# Altium schematic sheet H bridge.SchDoc
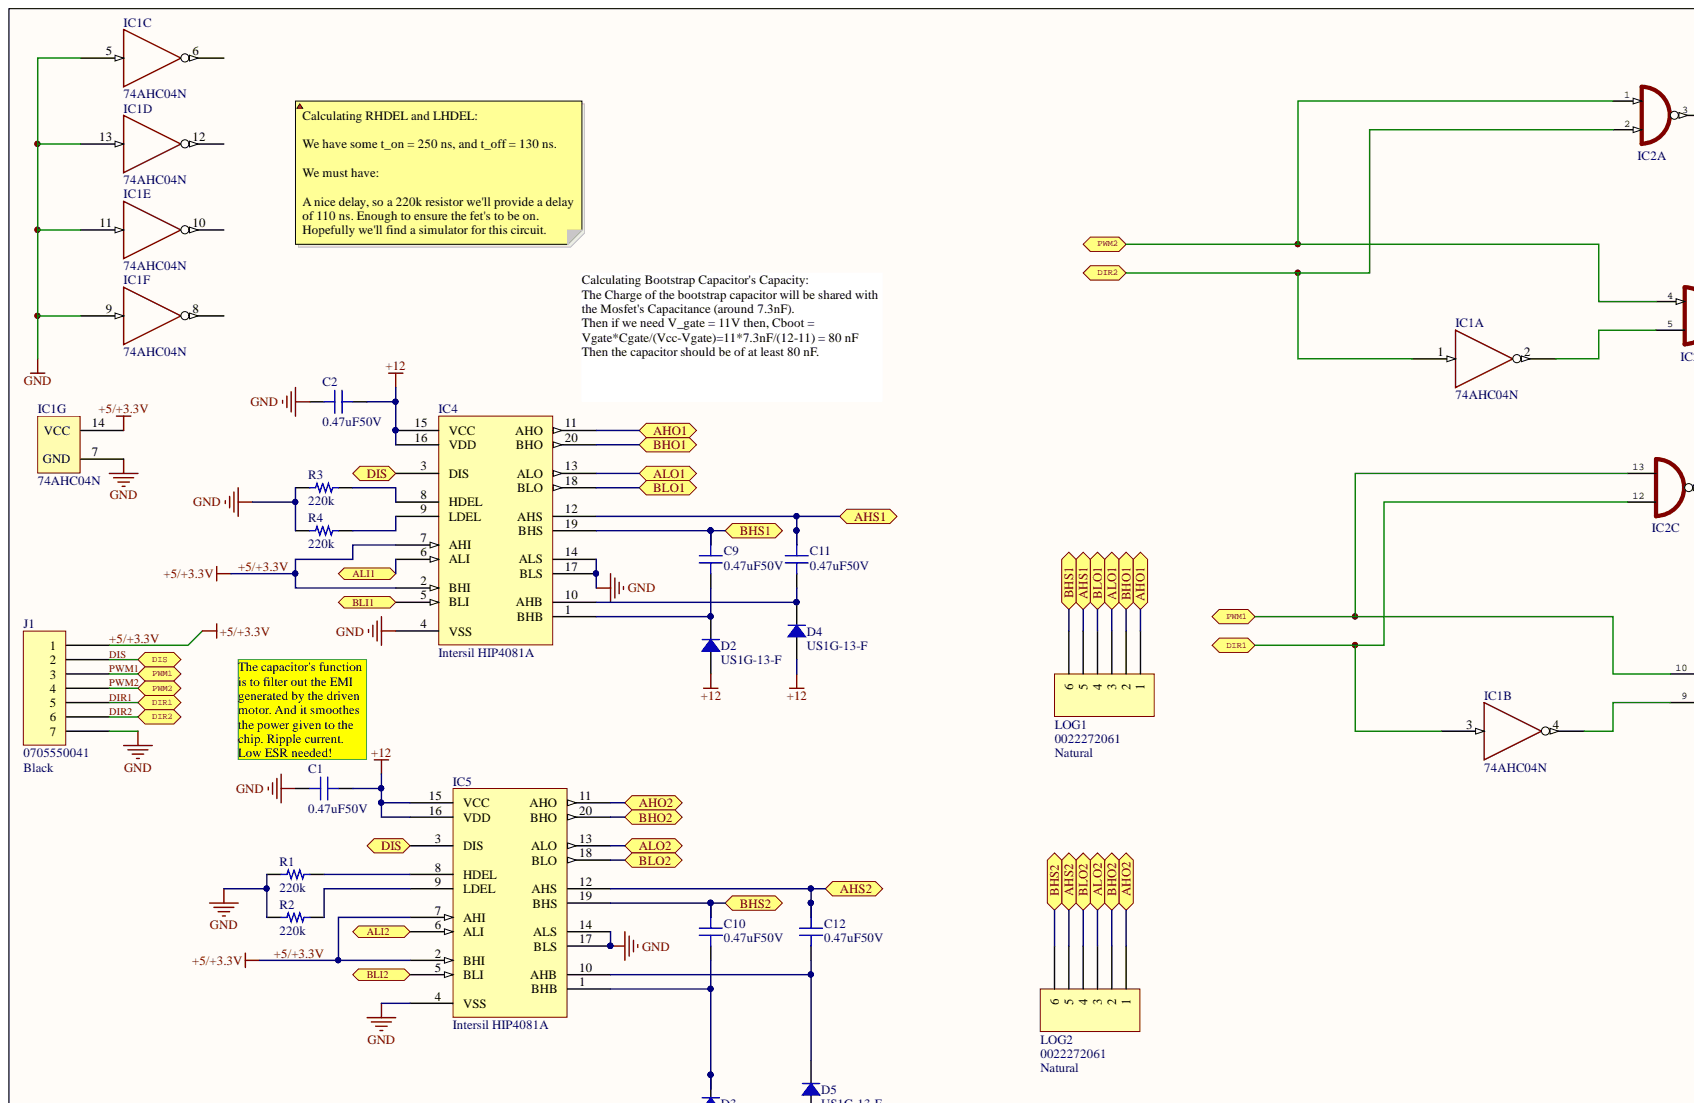
[diagram p0: H bridge.SchDoc, top left]
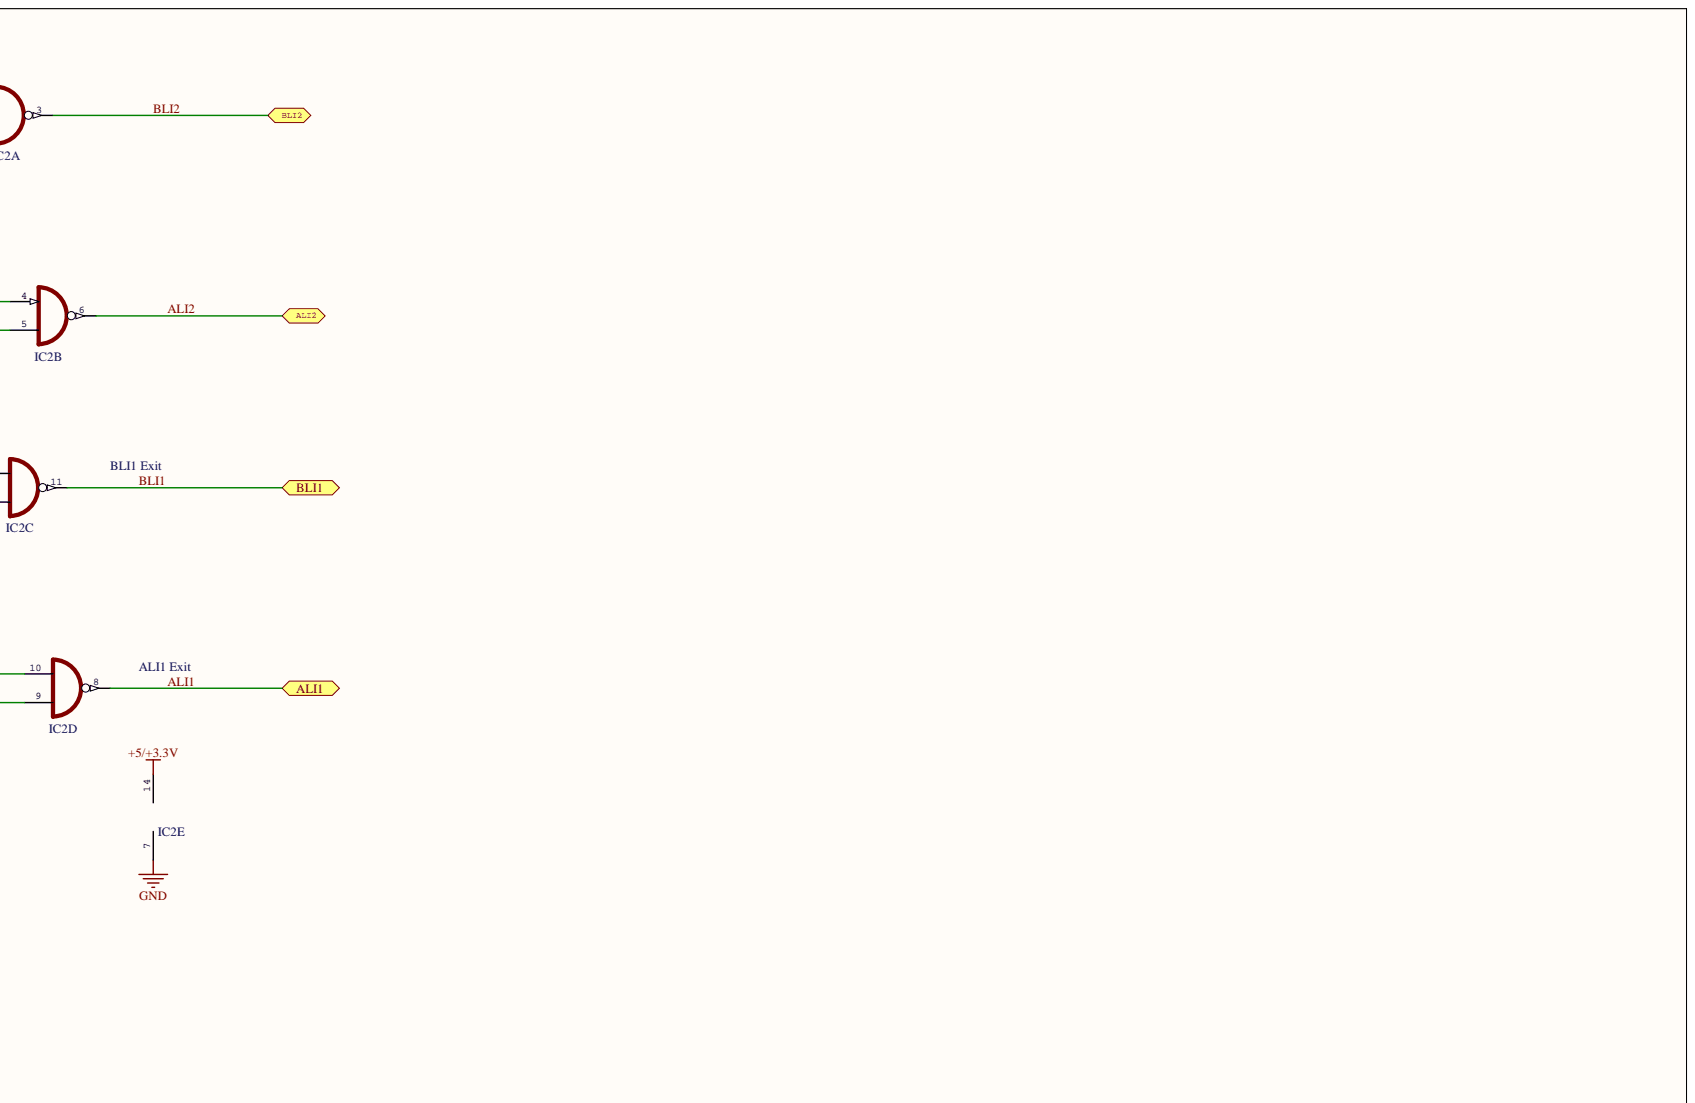
[diagram p1: H bridge.SchDoc, top right]
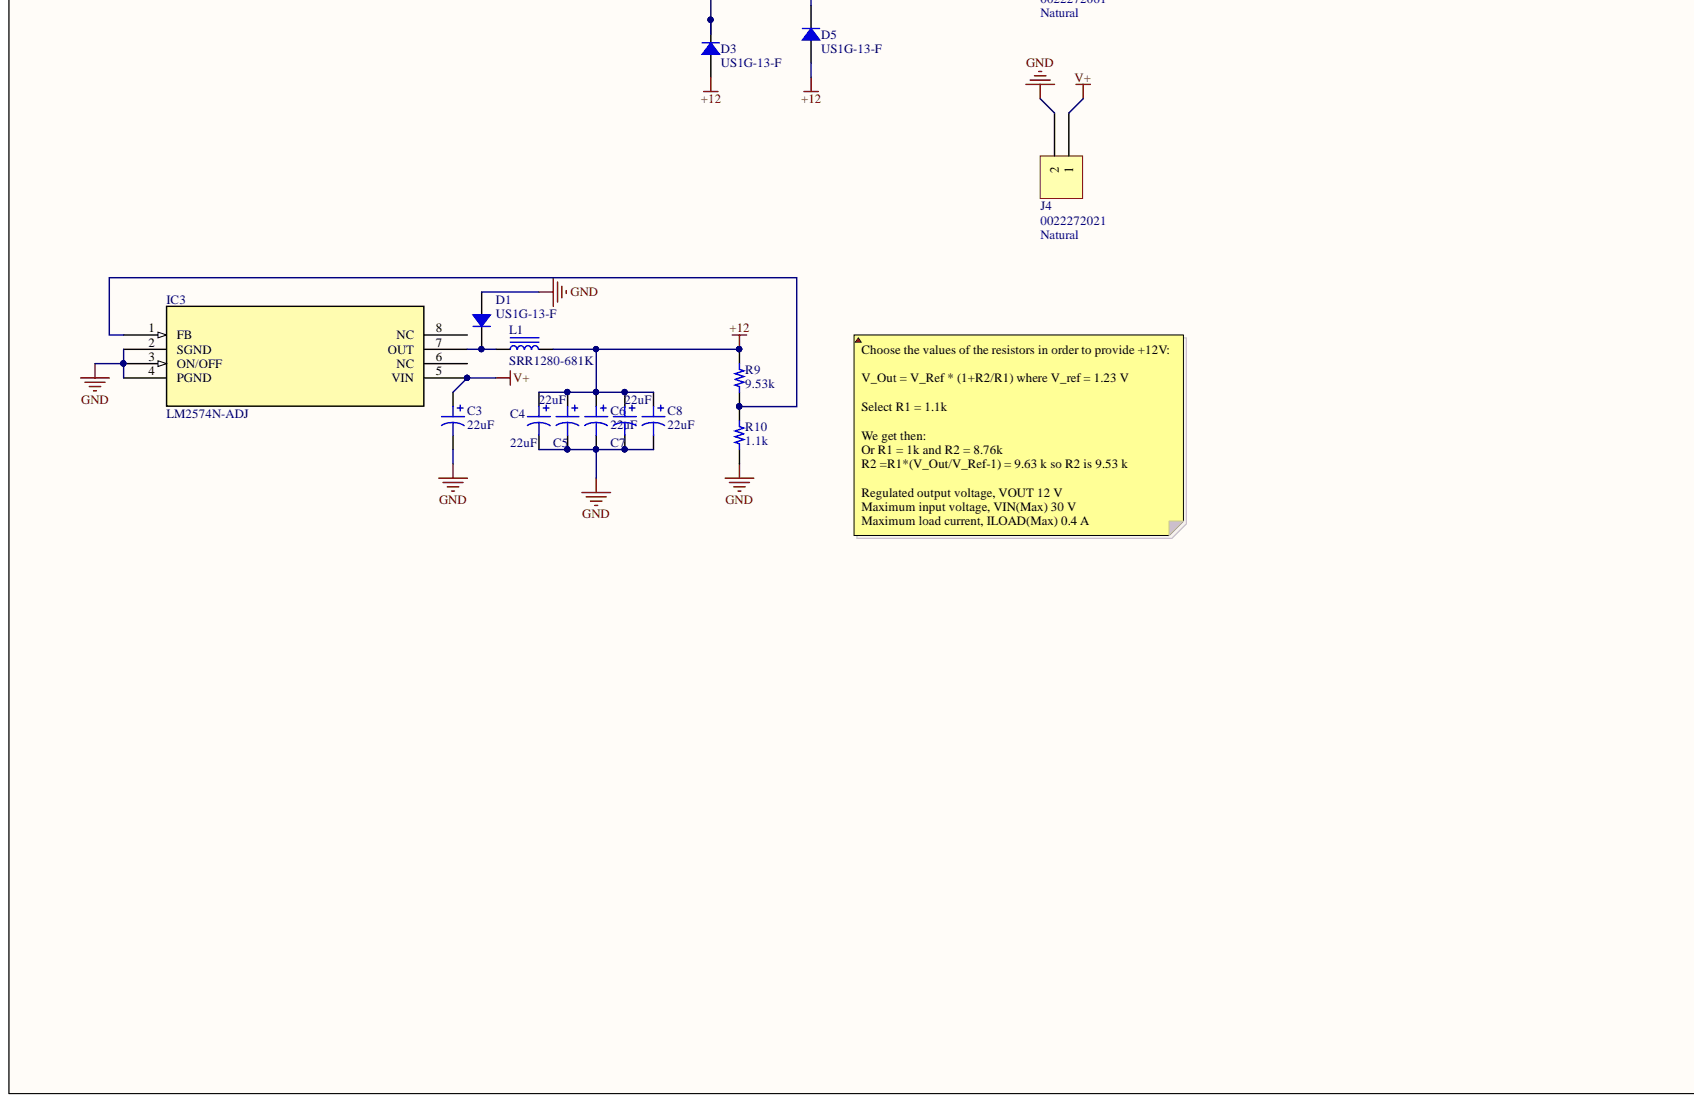
[diagram p2: H bridge.SchDoc, bottom left]

## Parts
C1  0.47uF50V  [CAP 1812/4532 1.1MM]
C2  0.47uF50V  [CAP 1812/4532 1.1MM]
C3  22uF  [CAP ALUM 8.3MM]
C4  22uF  [CAP ALUM 8.3MM]
C5  22uF  [CAP ALUM 8.3MM]
C6  22uF  [CAP ALUM 8.3MM]
C7  22uF  [CAP ALUM 8.3MM]
C8  22uF  [CAP ALUM 8.3MM]
C9  0.47uF50V  [CAP 1812/4532 1.1MM]
C10  0.47uF50V  [CAP 1812/4532 1.1MM]
C11  0.47uF50V  [CAP 1812/4532 1.1MM]
C12  0.47uF50V  [CAP 1812/4532 1.1MM]
D1  US1G-13-F  [DO214AC SMA]
D2  US1G-13-F  [DO214AC SMA]
D3  US1G-13-F  [DO214AC SMA]
D4  US1G-13-F  [DO214AC SMA]
D5  US1G-13-F  [DO214AC SMA]
IC1  74AHC04N  [DIL14]  (parts A,B,C,D,E,F,G)
IC2  PartNumber  [DIL14]  (value not drawn)  (parts A,B,C,D,E)
IC3  LM2574N-ADJ  [DIP8]
IC4  Intersil HIP4081A  [DIP20]
IC5  Intersil HIP4081A  [DIP20]
J1  0705550041  Colour: Black  [MOLEX SDA-70555-7PIN]
J4  0022272021  Colour: Natural  [MOLEX KK 0022272021]
L1  SRR1280-681K  [BOURNS SRR1280]
LOG1  0022272061  Colour: Natural  [MOLEX KK 0022272061]
LOG2  0022272061  Colour: Natural  [MOLEX KK 0022272061]
R1  220k  [RES 0603/1608]
R2  220k  [RES 0603/1608]
R3  220k  [RES 0603/1608]
R4  220k  [RES 0603/1608]
R9  9.53k  [RES 1206/3216]
R10  1.1k  [RES 1206/3216]

## Ports
AHO1, AHO2, AHS1, AHS2, ALI1, ALI2, ALO1, ALO2, BHO1, BHO2, BHS1, BHS2, BLI1, BLI2, BLO1, BLO2, DIR1, DIR2, DIS, PWM1, PWM2

## Nets
+12: C1.2 C2.2 C4.1 C5.1 C6.1 C7.1 C8.1 D2.1 D3.1 D4.1 D5.1 IC4.15(VCC) IC4.16(VDD) IC5.15(VCC) IC5.16(VDD) L1.2 R9.2
GND: C1.1 C2.1 C3.2 C4.2 C5.2 C6.2 C7.2 C8.2 D1.1 IC1.5 IC1.7(GND) IC1.9 IC1.11 IC1.13 IC2.7 IC3.2(SGND) IC3.3(ON/OFF) IC3.4(PGND) IC4.4(VSS) IC4.14(ALS) IC4.17(BLS) IC5.4(VSS) IC5.14(ALS) IC5.17(BLS) J1.7 J4.2 R1.1 R2.1 R3.1 R4.1 R10.2
V+: C3.1 IC3.5(VIN) J4.1
+5/+3.3V: IC1.14(VCC) IC2.14 IC4.2(BHI) IC4.7(AHI) IC5.2(BHI) IC5.7(AHI) J1.1
AHO1: IC4.11(AHO) LOG1.1 ; port AHO1
AHO2: IC5.11(AHO) LOG2.1 ; port AHO2
AHS1: C11.2 IC4.12(AHS) LOG1.5 ; port AHS1
AHS2: C12.2 IC5.12(AHS) LOG2.5 ; port AHS2
ALI1: IC2.8 IC4.6(ALI) ; port ALI1
ALI2: IC2.6 IC5.6(ALI) ; port ALI2
ALO1: IC4.13(ALO) LOG1.3 ; port ALO1
ALO2: IC5.13(ALO) LOG2.3 ; port ALO2
BHO1: IC4.20(BHO) LOG1.2 ; port BHO1
BHO2: IC5.20(BHO) LOG2.2 ; port BHO2
BHS1: C9.2 IC4.19(BHS) LOG1.6 ; port BHS1
BHS2: C10.2 IC5.19(BHS) LOG2.6 ; port BHS2
BLI1: IC2.11 IC4.5(BLI) ; port BLI1
BLI2: IC2.3 IC5.5(BLI) ; port BLI2
BLO1: IC4.18(BLO) LOG1.4 ; port BLO1
BLO2: IC5.18(BLO) LOG2.4 ; port BLO2
DIR1: IC1.3 IC2.12 J1.5 ; port DIR1
DIR2: IC1.1 IC2.2 J1.6 ; port DIR2
DIS: IC4.3(DIS) IC5.3(DIS) J1.2 ; port DIS
PWM1: IC2.10 IC2.13 J1.3 ; port PWM1
PWM2: IC2.1 IC2.4 J1.4 ; port PWM2
NetC9_1: C9.1 D2.2 IC4.1(BHB)
NetC10_1: C10.1 D3.2 IC5.1(BHB)
NetC11_1: C11.1 D4.2 IC4.10(AHB)
NetC12_1: C12.1 D5.2 IC5.10(AHB)
NetD1_2: D1.2 IC3.7(OUT) L1.1
NetIC1_2: IC1.2 IC2.5
NetIC1_4: IC1.4 IC2.9
NetIC3_1: IC3.1(FB) R9.1 R10.1
NetIC4_8: IC4.8(HDEL) R3.2
NetIC4_9: IC4.9(LDEL) R4.2
NetIC5_8: IC5.8(HDEL) R1.2
NetIC5_9: IC5.9(LDEL) R2.2

Not connected: IC1 6 8 10 12; IC3 6(NC) 8(NC)

## Library data of the parts
C1 C2 C9-C12 = C1812C474K5RACTU: Ceramic, Commercial-(CxxxxC), 0.47 uF, 10%, 50 V,; Manufacturer: Kemet; Database Table Name: Capacitor - Ceramic; TemperatureCoefficient: X7R; TemperatureRange: -55°C ~ 125°C; Value: 0.47uF; Voltage: 50V; Component Kind: Standard; ComponentLink1Description: Datasheet; ComponentLink1URL: http://www.kemet.com/docfinder?Partnumber=C1812C474K5RACTU; ComponentLink2Description: Digi-Key Listing; ComponentLink2URL: https://www.digikey.com/product-detail/en/kemet/C1812C474K5RACTU/399-3454-1-ND/721325; Device Package: 1812 (4532 Metric); Library Name: Celestial Library Database.DbLib; PartId: 21099; Part Number: C1812C474K5RACTU; Price: 0.97; Supplier 1: Digi-Key; Supplier Part Number 1: 399-3454-1-ND; Tolerance: 10%
C3-C8 = UWX1H220MCL1GB: CAP ALUM 22UF 20% 50V SMD; Manufacturer: Nichicon; Database Table Name: Capacitor - Aluminium; Lifetime @ Temp: 2000 Hrs @ 85C; Ripple Current: 52mA; TemperatureRange: -40C ~ 85C; Type: General Purpose; Component Kind: Standard; ComponentLink1Description: Datasheet; ComponentLink1URL: http://nichicon-us.com/english/products/pdfs/e-wx.pdf; ComponentLink2Description: Digi-Key listing; ComponentLink2URL: http://digikey.com/product-detail/en/UWX1H220MCL1GB/493-2136-2-ND/589877; Device Package: Radial, Can - SMD; Impedance: -; Library Name: Celestial Library Database.DbLib; PartId: 7298; Part Number: UWX1H220MCL1GB; Price: 0.1154; Supplier 1: Digi-Key; Supplier Part Number 1: 493-2136-2-ND; Tolerance: 20%; Value: 22uF; Voltage: 50V
D1-D5 = US1G-13-F: DIODE GEN PURP 400V 1A SMA; Manufacturer: Diodes Incorporated; Current Reverse Leakage @ Vr: 5uA @ 400V; Database Table Name: Diode - Rectifier; Reverse Recovery Time (trr): 50ns; Type: Standard; Voltage Forward (Vf): 1.3V @ 1A; Component Kind: Standard; ComponentLink1Description: Datasheet; ComponentLink1URL: http://www.diodes.com/_files/datasheets/ds16008.pdf; ComponentLink2Description: Digi-Key Listing; ComponentLink2URL: https://www.digikey.com/product-detail/en/diodes-incorporated/US1G-13-F/US1G-FDITR-ND/814656; Current Rectified: 1A; Device Package: DO-214AC, SMA; Junction Operating Temperature: -65C ~ 150C; Library Name: Celestial Library Database.DbLib; PartId: 16329; Part Number: US1G-13-F; Price: 0.0935; Supplier 1: Digi-Key; Supplier Part Number 1: US1G-FDITR-ND; Voltage DC Reverse (Vr): 400V
IC2 = SN74AC08N: IC GATE AND 4CH 2-INP 14-DIP; Manufacturer: Texas Instruments; Database Table Name: Logic - Gates And Inverters; High Logic Level: 2.1 V ~ 3.85 V; Low Logic Level: 0.9 V ~ 1.65 V; Number of Circuits: 4; Number of Entries: 2; Propagation Delay: 7.5 ns at 5 V, 50 pF; Supply Voltage: 2 V ~ 6 V; Component Kind: Standard; ComponentLink1Description: Datasheet; ComponentLink1URL: http://www.ti.com/lit/ds/symlink/sn74ac08.pdf; ComponentLink2Description: Digi-Key Listing; ComponentLink2URL: https://www.digikey.fr/product-detail/fr/texas-instruments/SN74AC08N/296-33637-5-ND/1575785; Library Name: Celestial Library Database.DbLib; Logic Type: AND; PartID: 1; Part Number: SN74AC08N; Series: 74AC; Supplier 1: Digi-Key; Supplier Part Number 1: 296-33637-5-ND
IC3 = LM2574N-ADJ: IC Switched Adjustable Regulator Through hole 1.23; Manufacturer: Texas Instruments; Manufacturer_Part_Number: lm2574n-adjnopb; Current Output: 500mA; Database Table Name: Voltage Regulator - Switchmode; Frequency - Switching: 52kHz; Function: Step-Down; Number Of Outputs: 1; Output Configuration: Positive; Synchronous Rectifier: No; Topology: Buck; Vendor: National Semiconductor; Voltage - Input (Max): 40V; Voltage - Input (Min): 4V; Voltage - Output (Max): 37V; Voltage - Output (Min/Fixed): 1.23V; Component Kind: Standard; ComponentLink1Description: Datasheet; ComponentLink1URL: http://www.ti.com/lit/ds/symlink/lm2574.pdf; ComponentLink2Description: Digi-Key Listing; ComponentLink2URL: https://www.digikey.com/product-detail/en/texas-instruments/LM2574N-ADJ-NOPB/LM2574N-ADJ-NOPB-ND/212614; Device Package: DPI 8; Library Name: Celestial Library Database.DbLib; Operating Temperature: -40°C ~ 125°C (TJ); Output Type: Adjustable; PartId: 16767; Part Number: LM2574N-ADJ; Price: 2.76; RefDes: RefDes; Series: SIMPLE SWITCHER®; Supplier 1: Digi-Key; Supplier Part Number 1: LM2574N-ADJ/NOPB-ND; Type: DC DC Adjustable Switching Regulators; Value: Value
J1 = 0705550041: CONN HEADER 7POS .100 R/A 15AU; Manufacturer: Molex, LLC; Database Table Name: Connector - Rectangular; Number Of Positions: 7; Pitch: 0.100" (2.54mm); Component Kind: Standard; ComponentLink1Description: Datasheet; ComponentLink1URL: http://www.molex.com/pdm_docs/sd/705550001_sd.pdf; ComponentLink2Description: Digi-Key Listing; ComponentLink2URL: http://www.digikey.ca/product-detail/en/0705550041/WM4180-ND/1643414; Contact Finish: Gold; Fastening Type: -; Library Name: Celestial Library Database.DbLib; Number Of Rows: 1; PartId: 7813; Part Number: 0705550041; Price: 3.99; Series: 70555; Supplier 1: Digi-Key; Supplier Part Number 1: WM4180-ND; Type: Through Hole, Right Angle
J4 = 0022272021: CONN HEADER 2POS .100 VERT TIN; Manufacturer: Molex, LLC; Database Table Name: Connector - Rectangular; Number Of Positions: 2; Pitch: 0.100" (2.54mm); Component Kind: Standard; ComponentLink1Description: Datasheet; ComponentLink1URL: http://www.molex.com/pdm_docs/sd/022272021_sd.pdf; ComponentLink2Description: Digi-Key Listing; ComponentLink2URL: http://www.digikey.com/product-search/en?keywords=22-27-2021; Contact Finish: Tin; Fastening Type: Friction Lock; Library Name: Celestial Library Database.DbLib; Number Of Rows: 1; PartId: 9719; Part Number: 0022272021; Price: 0.25; Series: KK 6410; Supplier 1: Digi-Key; Supplier Part Number 1: WM4111-ND; Type: Header, Unshrouded
L1 = SRR1280-681K: FIXED IND 680UH 750MA 1.3 OHM; Manufacturer: Bourns Inc.; Current - Saturation: 970mA; Database Table Name: Inductor - Power; DC Resistance (DCR): 1.3 Ohm Max; Frequency - Self Resonant: 1.7MHz; Material - Core: Ferrite; Q @ Freq: 26 @ 796kHz; Shielding: Shielded; Tolerance: 10%; Type: Wirewound; Component Kind: Standard; ComponentLink1Description: Datasheet; ComponentLink1URL: http://www.bourns.com/docs/Product-Datasheets/SRR1280.pdf; ComponentLink2Description: Digi-Key Listing; ComponentLink2URL: https://www.digikey.com/product-detail/en/bourns-inc/SRR1280-681K/SRR1280-681KLTR-ND/1970014; Current Rating: 750mA; Device Package: Nonstandard; Inductance: 680uH; Library Name: Celestial Library Database.DbLib; Operating Temperature: -40C ~ 125C; PartId: 15724; Part Number: SRR1280-681K; Price: 0.7737; Series: SRR1280; Supplier 1: Digi-Key; Supplier Part Number 1: SRR1280-681KLTR-ND; Value: 680uH
LOG1 LOG2 = 0022272061: CONN HEADER 6POS .100 VERT TIN; Manufacturer: Molex, LLC; Database Table Name: Connector - Rectangular; Number Of Positions: 6; Pitch: 0.100" (2.54mm); Component Kind: Standard; ComponentLink1Description: Datasheet; ComponentLink1URL: http://www.molex.com/pdm_docs/sd/022272061_sd.pdf; ComponentLink2Description: Digi-Key Listing; ComponentLink2URL: http://www.digikey.com/product-search/en?keywords=22-27-2061; Contact Finish: Tin; Fastening Type: Friction Lock; Library Name: Celestial Library Database.DbLib; Number Of Rows: 1; PartId: 9723; Part Number: 0022272061; Price: 0.47; Series: KK 6410; Supplier 1: Digi-Key; Supplier Part Number 1: WM4115-ND; Type: Header, Unshrouded
R1-R4 = ERJ-PA3J224V: RES SMD 220K OHM 5% 1/4W 0603; Manufacturer: Panasonic Electronic Components; Database Table Name: Resistor - Chip; PowerRating: 0.25W, 1/4W; TemperatureCoefficient: 200ppm/C; TemperatureRange: -55C ~ 155C; Component Kind: Standard; ComponentLink1Description: Datasheet; ComponentLink1URL: //media.digikey.com/pdf/Data%20Sheets/Panasonic%20Electronic%20Components/ERJ_PA2_P03_PA3_P06_P08_P14.pdf; ComponentLink2Description: Digi-Key Listing; ComponentLink2URL: https://www.digikey.com/product-detail/en/panasonic-electronic-components/ERJ-PA3J224V/P220KBZTR-ND/5036020; Device Package: 0603 (1608 Metric); Library Name: Celestial Library Database.DbLib; PartId: 11334; Part Number: ERJ-PA3J224V; Price: 0.0115; Supplier 1: Digi-Key; Supplier Part Number 1: P220KBZTR-ND; Tolerance: 5%; Value: 220k
  R1: Simulation: RES
R9 = ERJ-8ENF9531V: RES SMD 9.53K OHM 1% 1/4W 1206; Manufacturer: Panasonic Electronic Components; Database Table Name: Resistor - Chip; PowerRating: 0.25W, 1/4W; TemperatureCoefficient: 100ppm/C; TemperatureRange: -55C ~ 155C; Component Kind: Standard; ComponentLink1Description: Datasheet; ComponentLink1URL: http://industrial.panasonic.com/www-cgi/jvcr13pz.cgi?E+PZ+3+AOA0002+ERJ8ENF9531V+7+WW; ComponentLink2Description: Digi-Key listing; ComponentLink2URL: http://digikey.com/product-detail/en/ERJ-8ENF9531V/P9.53KFTR-ND/89055; Device Package: 1206 (3216 Metric); Library Name: Celestial Library Database.DbLib; PartId: 3197; Part Number: ERJ-8ENF9531V; Price: 0.0093; Supplier 1: Digi-Key; Supplier Part Number 1: P9.53KFTR-ND; Tolerance: 1%; Type: Thick Film; Value: 9.53k
R10 = ERJ-8ENF1101V: RES SMD 1.1K OHM 1% 1/4W 1206; Manufacturer: Panasonic Electronic Components; Database Table Name: Resistor - Chip; PowerRating: 0.25W, 1/4W; TemperatureCoefficient: 100ppm/C; TemperatureRange: -55C ~ 155C; Component Kind: Standard; ComponentLink1Description: Datasheet; ComponentLink1URL: http://industrial.panasonic.com/www-cgi/jvcr13pz.cgi?E+PZ+3+AOA0002+ERJ8ENF1101V+7+WW; ComponentLink2Description: Digi-Key listing; ComponentLink2URL: http://digikey.com/product-detail/en/ERJ-8ENF1101V/P1.10KFTR-ND/88871; Device Package: 1206 (3216 Metric); Library Name: Celestial Library Database.DbLib; PartId: 3127; Part Number: ERJ-8ENF1101V; Price: 0.0093; Supplier 1: Digi-Key; Supplier Part Number 1: P1.10KFTR-ND; Tolerance: 1%; Type: Thick Film; Value: 1.1k
IC1 = 74AHC04N: Component Kind: Standard; Library Name: 74ac-logic.SCHLIB
IC4 IC5 = Intersil HIP4081A: Component Kind: Standard; Library Name: Motor Driver.SchLib
  IC4: Simulation: H81L1
